# Altium schematic sheet AMS_schematic.SchDoc
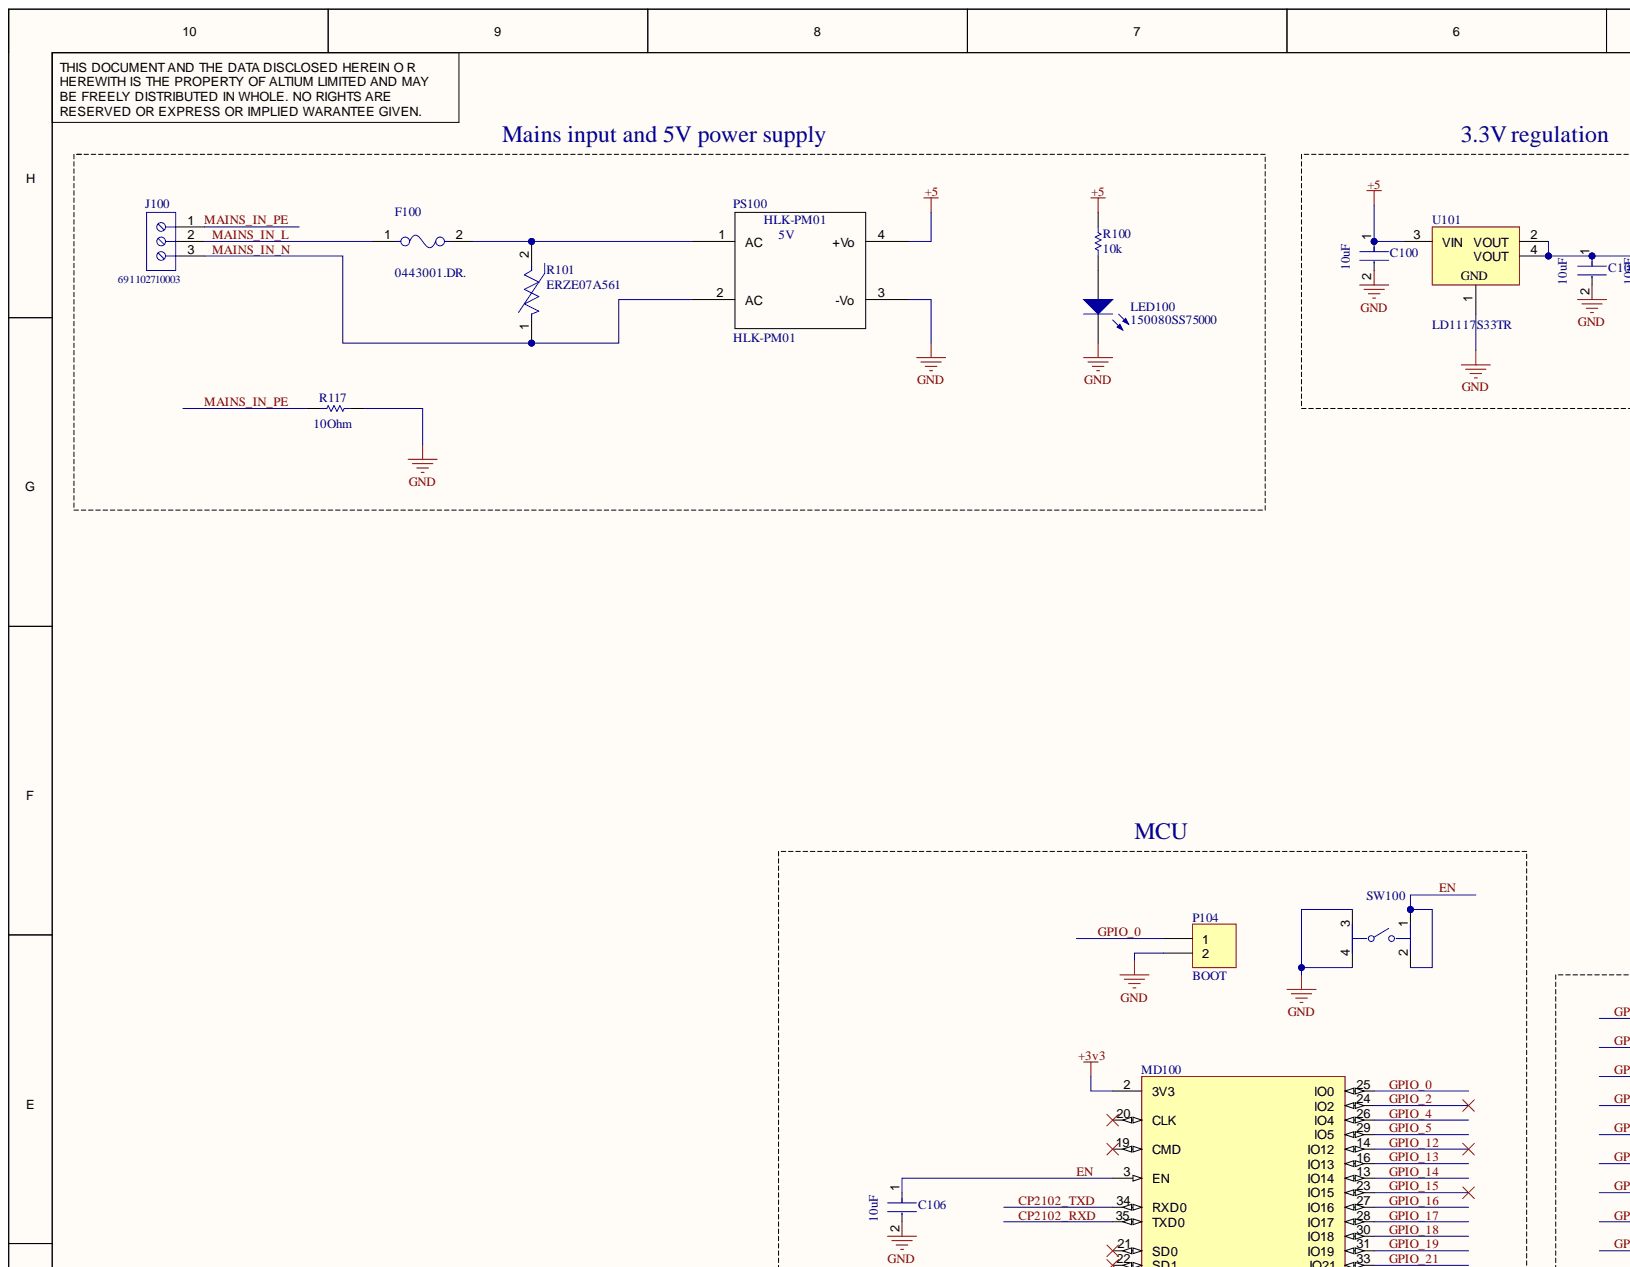
[diagram p0: AMS_schematic.SchDoc, top left]
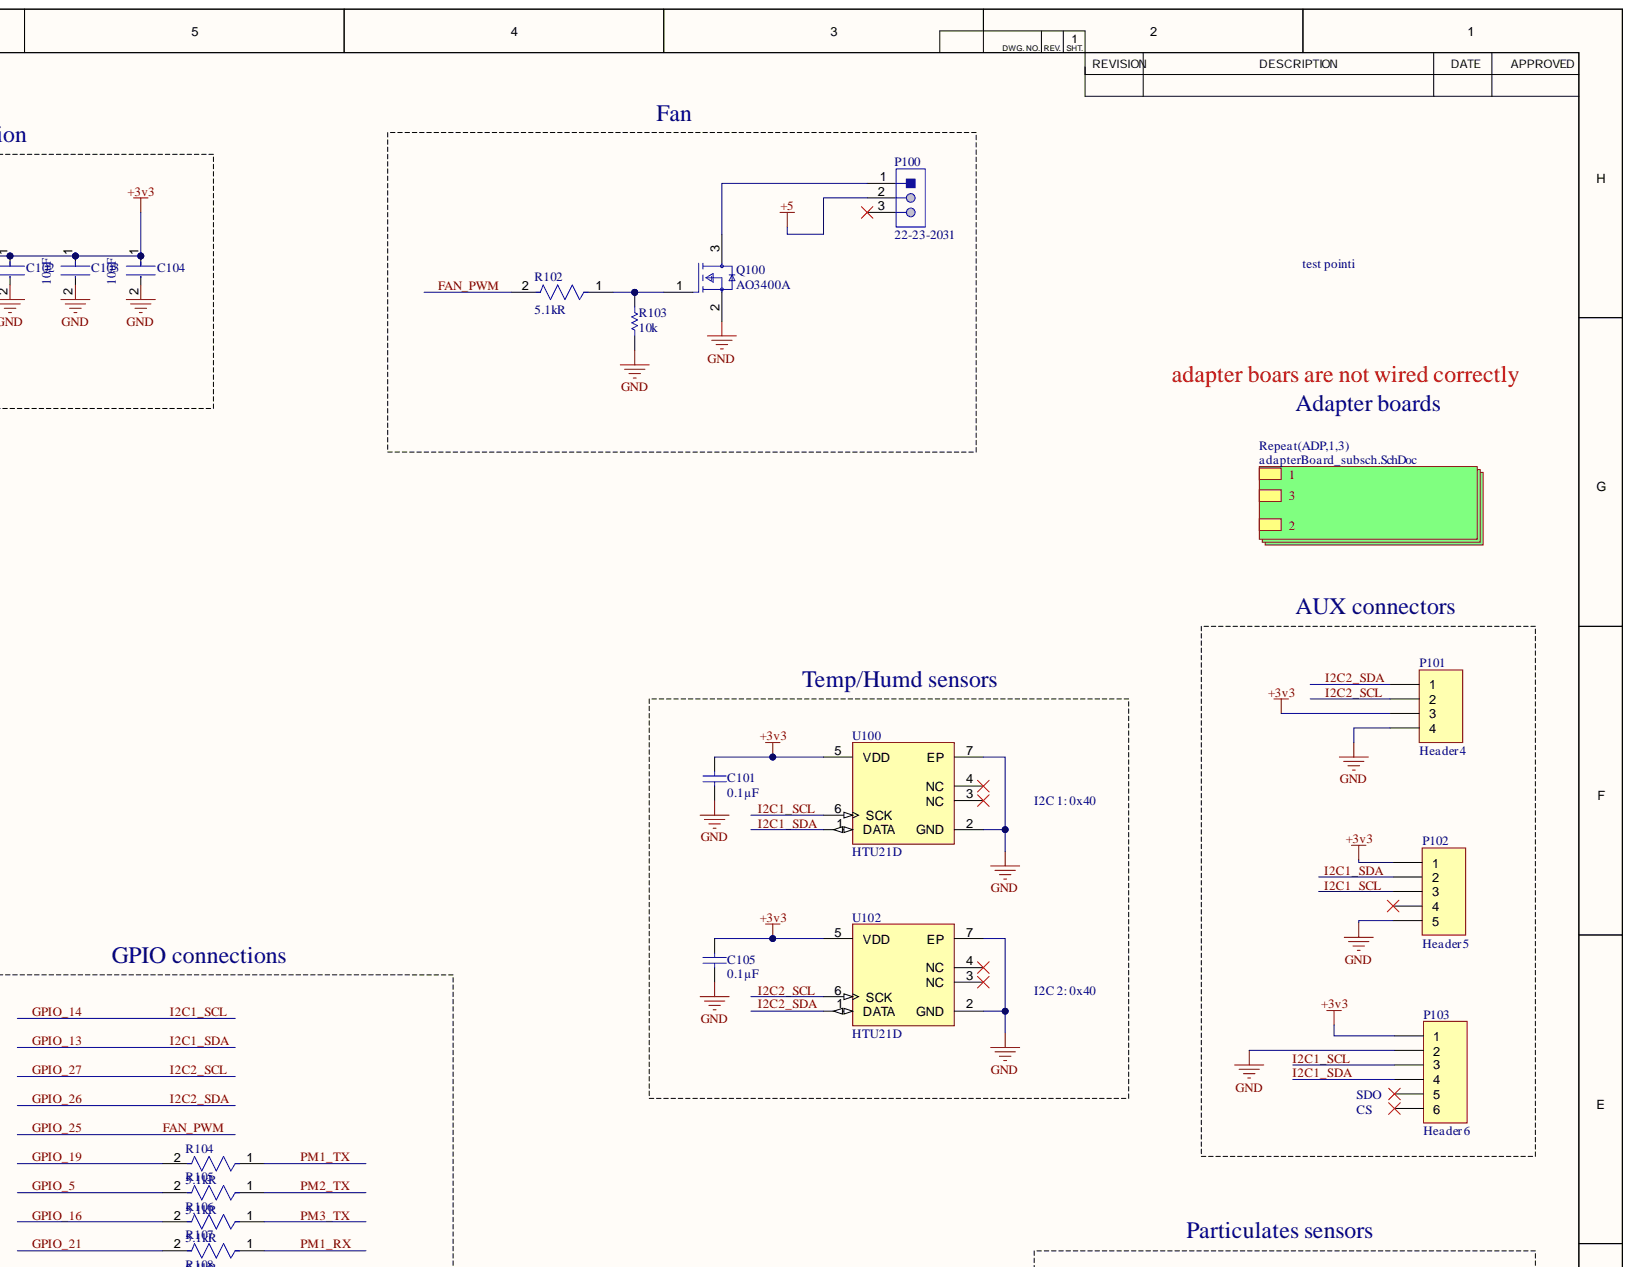
[diagram p1: AMS_schematic.SchDoc, top right]
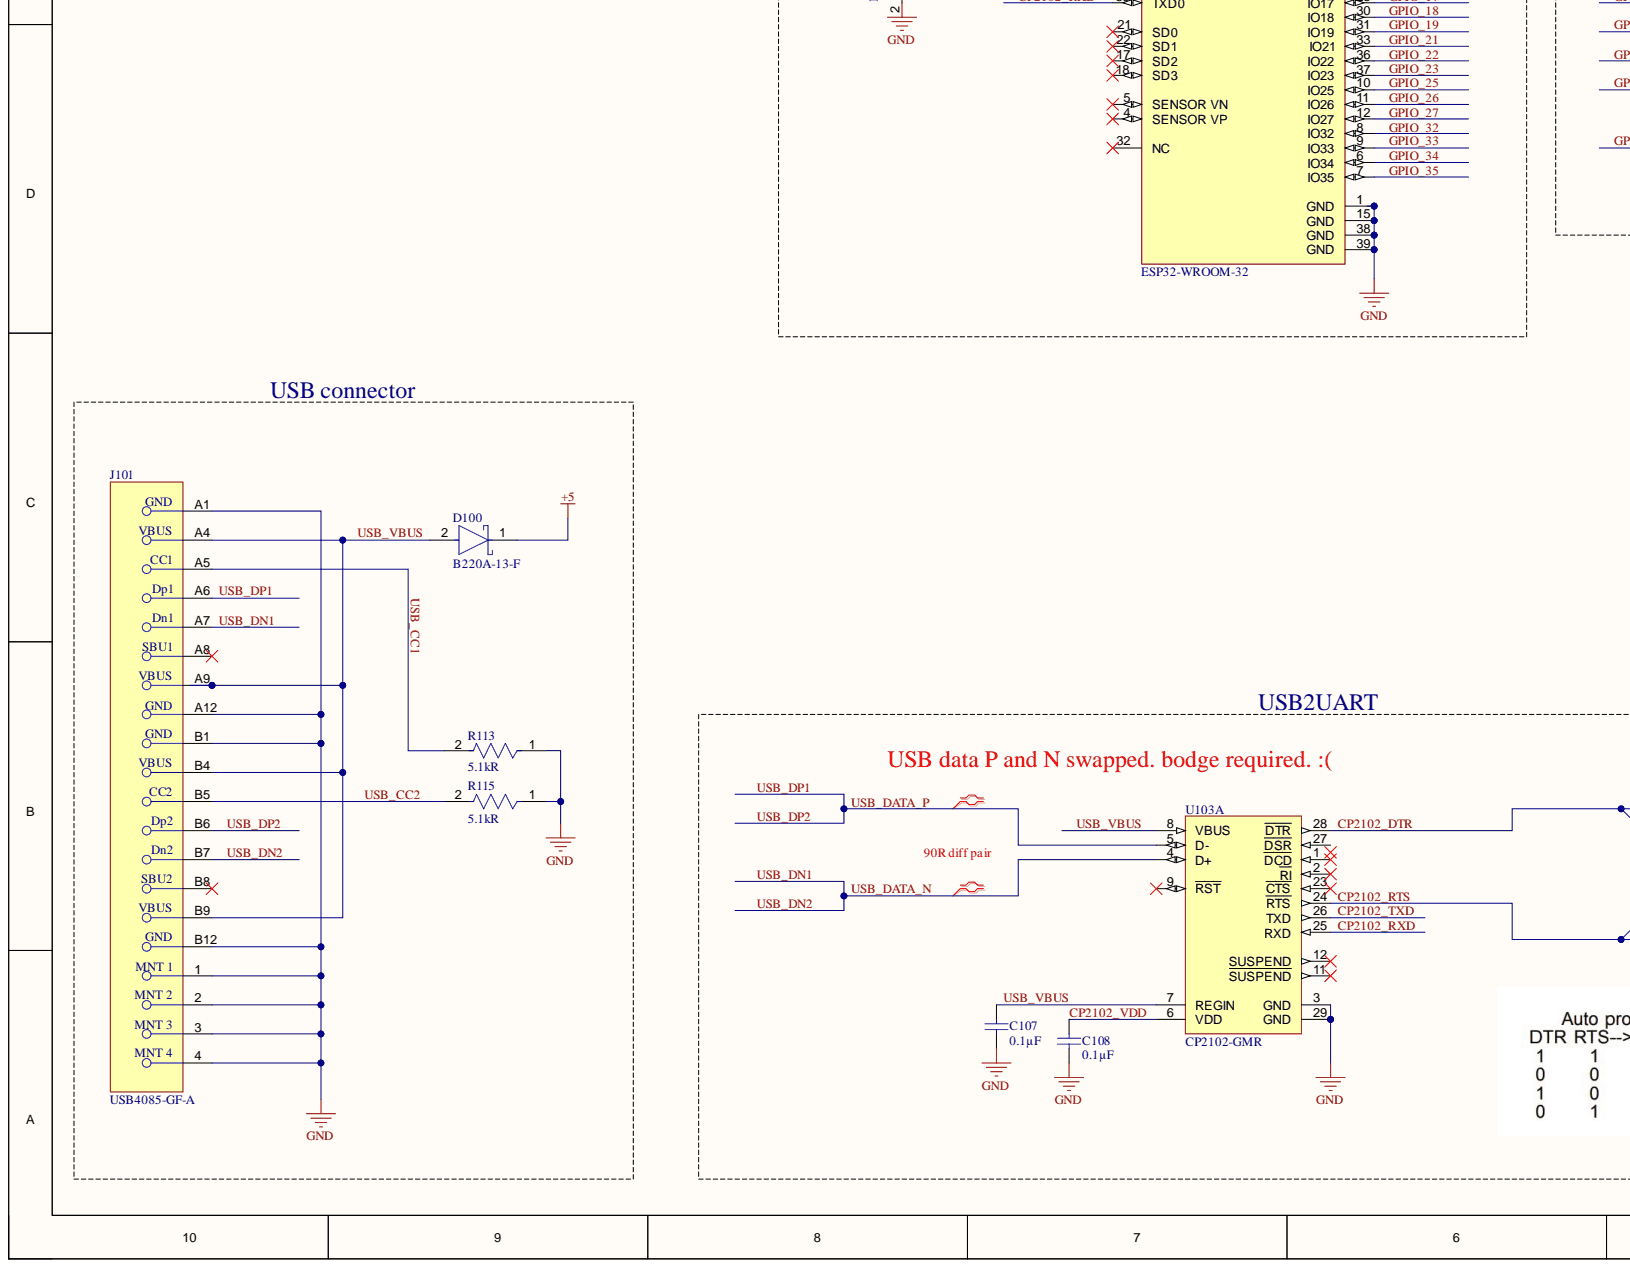
[diagram p2: AMS_schematic.SchDoc, bottom left]
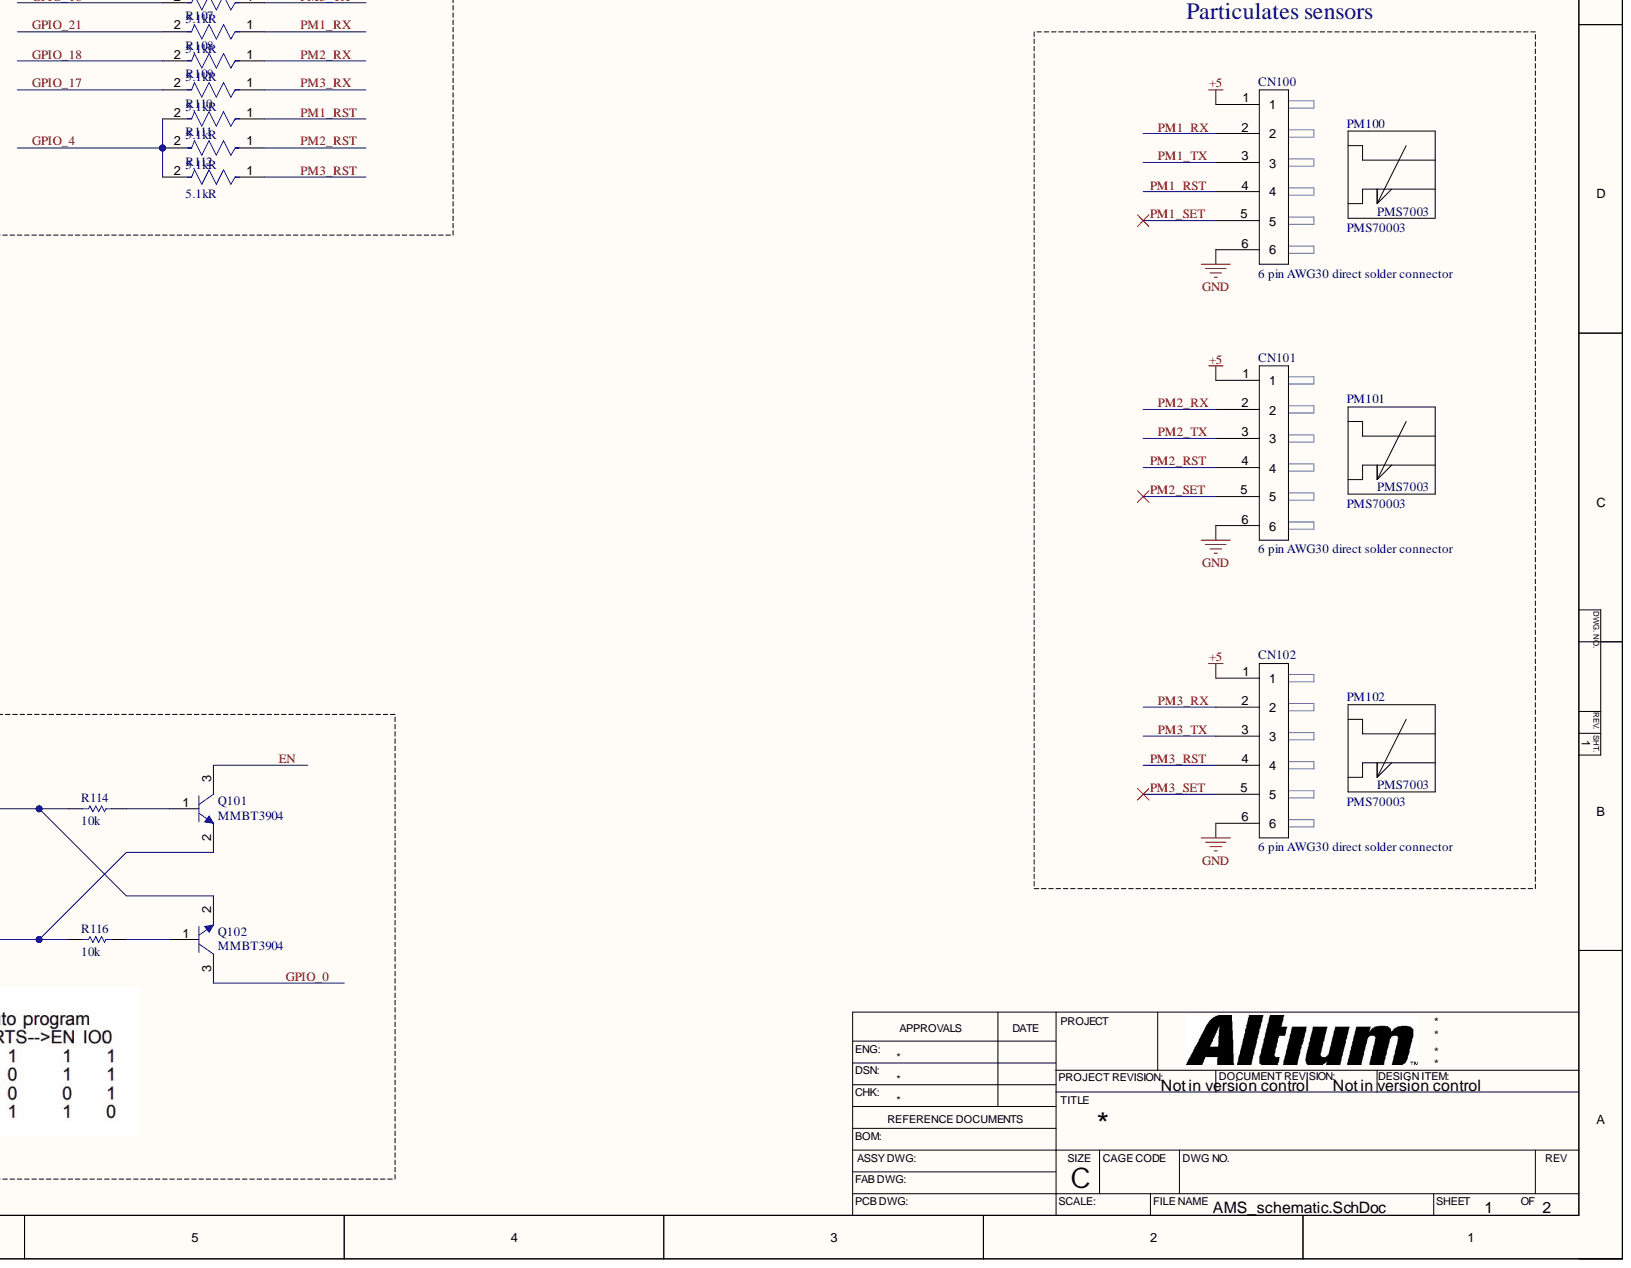
[diagram p3: AMS_schematic.SchDoc, bottom right]

## Parts
C100  Capacitance: 10uF  [FP-C3216-160-0_2-IPC_B]  (hidden comment: C3216X5R1C106K160AA)
C101  Capacitance: 0.1µF  [SAMS-C0603-2_M]  (hidden comment: CL10B104KB8NNNC)
C102  Capacitance: 10uF  [FP-C3216-160-0_2-IPC_B]  (hidden comment: C3216X5R1C106K160AA)
C103  Capacitance: 10uF  [FP-C3216-160-0_2-IPC_B]  (hidden comment: C3216X5R1C106K160AA)
C104  Capacitance: 10uF  [FP-C3216-160-0_2-IPC_B]  (hidden comment: C3216X5R1C106K160AA)
C105  Capacitance: 0.1µF  [SAMS-C0603-2_M]  (hidden comment: CL10B104KB8NNNC)
C106  Capacitance: 10uF  [FP-C3216-160-0_2-IPC_B]  (hidden comment: C3216X5R1C106K160AA)
C107  Capacitance: 0.1µF  [SAMS-C0603-2_M]  (hidden comment: CL10B104KB8NNNC)
C108  Capacitance: 0.1µF  [SAMS-C0603-2_M]  (hidden comment: CL10B104KB8NNNC)
CN100  6 pin AWG30 direct solder connector  [5 pin AWG30 direct solder connector]
CN101  6 pin AWG30 direct solder connector  [5 pin AWG30 direct solder connector]
CN102  6 pin AWG30 direct solder connector  [5 pin AWG30 direct solder connector]
D100  B220A-13-F  [FP-SMA-MFG]
F100  0443001.DR.  [FP-3403_0163_11-MFG]
J100  691102710003  [691102710003]
J101  USB4085-GF-A  [FP-USB4085-GF-A-MFG]
LED100  150080SS75000  [0805_A]
MD100  ESP32-WROOM-32  [FP-ESP32-WROOM-32-MFG]
P100  22-23-2031  [MOLX-22-23-2031_V]
P101  Header 4  [HDR1X4]
P102  Header 5  [HDR1X5]
P103  Header 6  [HDR1X6]
P104  BOOT  [HDR1X2]
PM100  PMS70003  [PMS7003 standing]
PM101  PMS70003  [PMS7003 standing]
PM102  PMS70003  [PMS7003 standing]
PS100  HLK-PM01  [HLK-PMxx]
Q100  AO3400A  [FP-SOT23-MFG]
Q101  MMBT3904  [ONSC-SOT-23-3-318-08_V]
Q102  MMBT3904  [ONSC-SOT-23-3-318-08_V]
R100  10k  [RESC2013X60X35NL10T20]
R101  ERZE07A561  [FP-ERZE07A561-MFG]
R102  Resistance: 5.1kR  [FP-CRCW0805-e3-MFG]  (hidden comment: CRCW08055K10FKEA)
R103  10k  [RESC2013X60X35NL10T20]
R104  Resistance: 5.1kR  [FP-CRCW0805-e3-MFG]  (hidden comment: CRCW08055K10FKEA)
R105  Resistance: 5.1kR  [FP-CRCW0805-e3-MFG]  (hidden comment: CRCW08055K10FKEA)
R106  Resistance: 5.1kR  [FP-CRCW0805-e3-MFG]  (hidden comment: CRCW08055K10FKEA)
R107  Resistance: 5.1kR  [FP-CRCW0805-e3-MFG]  (hidden comment: CRCW08055K10FKEA)
R108  Resistance: 5.1kR  [FP-CRCW0805-e3-MFG]  (hidden comment: CRCW08055K10FKEA)
R109  Resistance: 5.1kR  [FP-CRCW0805-e3-MFG]  (hidden comment: CRCW08055K10FKEA)
R110  Resistance: 5.1kR  [FP-CRCW0805-e3-MFG]  (hidden comment: CRCW08055K10FKEA)
R111  Resistance: 5.1kR  [FP-CRCW0805-e3-MFG]  (hidden comment: CRCW08055K10FKEA)
R112  Resistance: 5.1kR  [FP-CRCW0805-e3-MFG]  (hidden comment: CRCW08055K10FKEA)
R113  Resistance: 5.1kR  [FP-CRCW0805-e3-MFG]  (hidden comment: CRCW08055K10FKEA)
R114  10k  [RESC2013X60X35NL10T20]
R115  Resistance: 5.1kR  [FP-CRCW0805-e3-MFG]  (hidden comment: CRCW08055K10FKEA)
R116  10k  [RESC2013X60X35NL10T20]
R117  Resistance: 10Ohm  [RESC2013X60X35ML10T20]  (hidden comment: RC0805FR-0710RL)
SW100  PTS645SM43SMTR92LFS  [FP-PTS645SM43SMTR92LFS-MFG]  (value not drawn)
U100  HTU21D  [FP-HTU21D-IPC_B]
U101  LD1117S33TR  [SOT-223_L]
U102  HTU21D  [FP-HTU21D-IPC_B]
U103  CP2102-GMR  [SLAB-QFN-28-3125x3125TP_M]  (part A)

## Nets
3: C101.2 C102.1 C103.1 C104.1 C105.2 MD100.2(3V3) P101.3 P102.1 P103.1 U100.5(VDD) U101.2(VOUT) U101.4(VOUT) U102.5(VDD)
+5: C100.1 CN100.1 CN101.1 CN102.1 D100.1 P100.2 PS100.4(+Vo) R100.2 U101.3(VIN)
GND: C100.2 C101.1 C102.2 C103.2 C104.2 C105.1 C106.2 C107.1 C108.1 CN100.6 CN101.6 CN102.6 J101.1 J101.2 J101.3 J101.4 J101.A1 J101.A12 J101.B1 J101.B12 LED100.1 MD100.1(GND) MD100.15(GND) MD100.38(GND) MD100.39(GND) P101.4 P102.5 P103.2 P104.2 PS100.3(-Vo) Q100.2 R103.1 R113.1 R115.1 R117.2 SW100.3 SW100.4 U100.2(GND) U100.7(EP) U101.1 U102.2(GND) U102.7(EP) U103.3(GND) U103.29(GND)
CP2102_DTR: Q102.2 R114.1 U103.28(DTR)
CP2102_RTS: Q101.2 R116.1 U103.24(RTS)
CP2102_RXD: MD100.35(TXD0) U103.25(RXD)
CP2102_TXD: MD100.34(RXD0) U103.26(TXD)
CP2102_VDD: C108.2 U103.6(VDD)
EN: C106.1 MD100.3(EN) Q101.3 SW100.1 SW100.2
FAN_PWM: MD100.10(IO25) R102.2
GPIO_0: MD100.25(IO0) P104.1 Q102.3
GPIO_2: MD100.24(IO2)
GPIO_4: MD100.26(IO4) R110.2 R111.2 R112.2
GPIO_5: MD100.29(IO5) R105.2
GPIO_12: MD100.14(IO12)
GPIO_13: MD100.16(IO13) P102.2 P103.4 U100.1(DATA)
GPIO_14: MD100.13(IO14) P102.3 P103.3 U100.6(SCK)
GPIO_15: MD100.23(IO15)
GPIO_16: MD100.27(IO16) R106.2
GPIO_17: MD100.28(IO17) R109.2
GPIO_18: MD100.30(IO18) R108.2
GPIO_19: MD100.31(IO19) R104.2
GPIO_21: MD100.33(IO21) R107.2
GPIO_22: MD100.36(IO22)
GPIO_23: MD100.37(IO23)
GPIO_26: MD100.11(IO26) P101.1 U102.1(DATA)
GPIO_27: MD100.12(IO27) P101.2 U102.6(SCK)
GPIO_32: MD100.8(IO32)
GPIO_33: MD100.9(IO33)
GPIO_34: MD100.6(IO34)
GPIO_35: MD100.7(IO35)
MAINS_IN_L: F100.1 J100.2
MAINS_IN_N: J100.3 PS100.2(AC) R101.1
MAINS_IN_PE: J100.1 R117.1
PM1_RST: CN100.4 R110.1
PM1_RX: CN100.2 R107.1
PM1_SET: CN100.5
PM1_TX: CN100.3 R104.1
PM2_RST: CN101.4 R111.1
PM2_RX: CN101.2 R108.1
PM2_SET: CN101.5
PM2_TX: CN101.3 R105.1
PM3_RST: CN102.4 R112.1
PM3_RX: CN102.2 R109.1
PM3_SET: CN102.5
PM3_TX: CN102.3 R106.1
USB_CC1: J101.A5 R113.2
USB_CC2: J101.B5 R115.2
USB_DATA_N: J101.A7 J101.B7 U103.4(D+)
USB_DATA_P: J101.A6 J101.B6 U103.5(D-)
USB_VBUS: C107.2 D100.2 J101.A4 J101.A9 J101.B4 J101.B9 U103.7(REGIN) U103.8(VBUS)
NetF100_2: F100.2 PS100.1(AC) R101.2
NetLED100_2: LED100.2 R100.1
NetP100_1: P100.1 Q100.3
NetQ100_1: Q100.1 R102.1 R103.2
NetQ101_1: Q101.1 R114.2
NetQ102_1: Q102.1 R116.2
1:
2:

Not connected: J101 A8 B8; MD100 4(SENSOR_VP) 5(SENSOR_VN) 17(SD2) 18(SD3) 19(CMD) 20(CLK) 21(SD0) 22(SD1) 32(NC); P100 3; P102 4; P103 5 6; U100 3(NC) 4(NC); U102 3(NC) 4(NC); U103 1(DCD) 2(RI) 9(RST) 11(SUSPEND) 12(SUSPEND) 23(CTS) 27(DSR)

## Library data of the parts
C100 C102-C104 C106 = CMP-08246-003443-1: Multilayer Ceramic Capacitors 10uF ±10% 16V X5R SMD 1206; Case Code (Imperial): 1206; Case Code (Metric): 3216; Case/Package: 1206; Component Kind: Standard; Construction: Flat; Depth: 1.6mm; Dielectric: X5R; Dielectric Material: Ceramic; Height: 1.6mm; Lead Free: Lead Free; Length: 3.2mm; Library Name: Altium Content Vault; Max Operating Temperature: 85°C; Min Operating Temperature: -55°C; Mount: Surface Mount; Package Quantity: 1; Packaging: Tape and Reel; Radiation Hardening: No; REACH SVHC: No SVHC; Resistor Type: Ceramic; RoHS Compliant: Yes; Series: C; Termination: SMD/SMT; Thickness: 0.071inch; Tolerance: 10%; Voltage: 16V; Voltage Rating: 16V; Voltage Rating (DC): 16V; Weight: 0.000571oz; Width: 0.063inch
C101 C105 C107 C108 = CMP-2000-05332-1: Multi-layer Ceramic Capacitor, -55 to 125 degC, 2-Pin SMD (0603), RoHS, Tape and Reel; Manufacturer: Samsung Electro-Mechanics; Dielectric: X7R; Rated Voltage: 50V; Tolerance (%): ±10%; Case Size/Dimension: 0603; Component Kind: Standard; Datasheet URL: https://datasheet.ciiva.com/29198/456651-da-01-en-vs-kondensator-0605-29198839.pdf; Datasheet Version: Nov-10; Library Name: Altium Content Vault; Manufacturer URL: http://www.samsungsem.com/global/index.jsp
D100 = CMP-12790-000072-1: DIODE SCHOTTKY 20V 2A SMA; Capacitance: 200pF; Element Configuration: Single; Forward Voltage: 500mV; Max Forward Surge Current (Ifsm): 50A; Number of Elements: 1; Peak Reverse Current: 500uA; Polarity: Standard; Average Rectified Current: 2A; Case/Package: SMA; Component Kind: Standard; Contact Plating: Tin; Current Rating: 2A; Forward Current: 2A; Height: 2.1mm; Lead Free: Lead Free; Length: 4.6mm; Library Name: Altium Content Vault; Max Operating Temperature: 150°C; Max Repetitive Reverse Voltage (Vrrm): 20V; Max Reverse Voltage (DC): 20V; Min Operating Temperature: -65°C; Mount: Surface Mount; Number of Pins: 2; Number of Terminals: 2; Output Current: 2A; Package Quantity: 5000; Packaging: Tape and Reel; Peak Non-Repetitive Surge Current: 50A; Radiation Hardening: No; REACH SVHC: No SVHC; Termination: SMD/SMT; Voltage Rating (DC): 20V; Weight: 0.002258oz; Width: 2.92mm
F100 = CMP-26293-000009-1: FUSE, SMD, 1A, SLOW BLOW; Manufacturer: Schurter Inc; Manufacturer Part Number: 3403.0163.11; Fuse I2T: 0.54A2Sec; Fuse Voltage Drop: 600mV; Max Fuse Ac Voltage: 250V; Max Fuse Dc Voltage: 125V; Ambient Temperature Range High: +125°C; Ambient Temperature Range Low: -55°C; Automotive: No; Category: Fuse; Component Kind: Standard; ComponentLink2Description: Datasheet; ComponentLink2URL: https://www.farnell.com/datasheets/717394.pdf; Device Class L1: Circuit Protection; Device Class L2: Fuses; Device Class L3: unset; Digikey Description: FUSE BRD MNT 500MA 250VAC 125VDC; Farnell #: 1664602; Fuse Blow Speed: Slow; Fuse Current: 1A; Height: 3mm; Lead Free: Yes; Library Name: Altium Content Vault; Mouser Description: Surface Mount Fuses UMT 250 500mA 277V Slow; Octopart: https://octopart.com/3403.0163.11-schurter-65395; Package: 0; RoHS: TRUE; Voltage Rating: 125V
J100 = CMP-1502-03259-1: Series 102 - 5.00 mm Horizontal Entry Modular with Pressure Clamp WR-TBL, 3 pin; Manufacturer: Wurth Elektronik; Pin Number: 3; Pitch: 5.00mm; Component Kind: Standard; Datasheet URL: http://katalog.we-online.de/em/datasheet/6911027100xx.pdf; Farnell #: 1641935; Library Name: Altium Content Vault; Manufacturer URL: http://www.we-online.de; Mounting Technology: THT; Operating Temperature: -40to105degC; Package Reference: 691102710003; Packaging: Box; Part Number: 691102710003; Publisher: WurthElektronik
J101 = CMP-196119-000001-1: CONN RCPT USB2.0 TYPEC 16POS; Manufacturer: Global Connector Technology; Conn Orientation: Right Angle; Current Rating: 4.25A; Is Female: yes; Number Of Contacts: 16; Pitch: 0.85mm; Shielding: true; Voltage Rating: 20V; Automotive: No; Category: Conn; Component Kind: Standard; ComponentLink2Description: Datasheet; ComponentLink2URL: https://gct.co/files/drawings/usb4085.pdf; Contact Material: Gold,Nickel; Device Class L1: Connectors; Device Class L2: USB Connectors; Device Class L3: unset; Digikey Description: CONN RCPT USB2.0 TYPEC 16POS; Farnell #: 2924867; Height: 3.66mm; Lead Free: Yes; Library Name: Altium Content Vault; Manufacturer Part Number: USB4085-GF-A; Octopart: https://octopart.com/usb4085-gf-a-global+connector+technology-94773699; Package: 0; RoHS: TRUE; Temperature: -40°C to +85°C; Temperature Range High: +85°C; Temperature Range Low: -40°C; Usb Standard: USB 2.0
LED100 = CMP-1426-00010-1: SMD mono-color Chip LED, WL-SMCW, Super Red; Manufacturer: Wuerth Elektronik; Color: Super Red; Component Kind: Standard; ComponentLink1Description: Manufacturer URL; ComponentLink1URL: http://www.we-online.com; ComponentLink2Description: Datasheet; ComponentLink2URL: http://katalog.we-online.com/led/datasheet/150080SS75000.pdf; Library Name: Altium Content Vault; PackageReference: 0805_A; PartNumber: 150080SS75000
MD100 = CMP-194065-000008-1: RX TXRX MOD WIFI SURFACE MOUNT; Data Rate: 150Mbps; Frequency: 2.5GHz; Operating Supply Voltage: 3.6V; Sensitivity: -98dBm; Case/Package: SMD/SMT; Component Kind: Standard; Library Name: Altium Content Vault; Max Operating Temperature: 85°C; Min Operating Temperature: -40°C; Mount: Surface Mount; Package Quantity: 550; Packaging: Cut Tape; Weight: 0.088185oz
P100 = CMP-2000-05242-1: Male Header, Pitch 2.54 mm, 1 x 3 Position, Height 8.18 mm, Tail Length 3.56 mm, RoHS, Bulk; Brand: Molex; Current Rating: 4A; Mounting Angle: Vertical; Pitch: 2.54mm; Voltage Rating: 250V; Component Kind: Standard; Contact Gender: Pin(Male); Contact Material: Brass; Contact Plating: Tin; Datasheet URL: https://datasheet.ciiva.com/19472/232274-19472863.pdf; Datasheet Version: Sep-01; Factory Pack Quantity: 16000; Housing Material: Nylon; Library Name: Altium Content Vault; Manufacturer: Molex; Manufacturer URL: http://www.molex.com/; Mounting Style: ThroughHole; Number of Positions: 3Position; Number of Rows: 1Row; Packaging: Bulk; Product: Headers; Product Category: Headers&WireHousings; RoHS: Details; Series: 6373; Termination Style: ThroughHole; Tradename: KK; Type: Locking
P101 = Header 4: Header, 4-Pin; Component Kind: Standard; LatestRevisionDate: 17-Jul-2002; LatestRevisionNote: Re-released for DXP Platform.; Library Name: Miscellaneous Connectors.IntLib; Signal Integrity: Connector; Publisher: Altium Limited
P102 = Header 5: Header, 5-Pin; Component Kind: Standard; LatestRevisionDate: 17-Jul-2002; LatestRevisionNote: Re-released for DXP Platform.; Library Name: Miscellaneous Connectors.IntLib; Signal Integrity: Connector; Publisher: Altium Limited
P103 = Header 6: Header, 6-Pin; Component Kind: Standard; LatestRevisionDate: 17-Jul-2002; LatestRevisionNote: Re-released for DXP Platform.; Library Name: Miscellaneous Connectors.IntLib; Signal Integrity: Connector; Publisher: Altium Limited
P104 = Header 2: Header, 2-Pin; Component Kind: Standard; LatestRevisionDate: 17-Jul-2002; LatestRevisionNote: Re-released for DXP Platform.; Library Name: Miscellaneous Connectors.IntLib; Signal Integrity: Connector; Publisher: Altium Limited
PM100-PM102 = PMS70003: PM1/2.5/10 airborne particle sensor. Silk tape mounting pattern only. Needs sepparate data connector.; Component Kind: Standard; Library Name: planinsekLib.IntLib
PS100 = HLK-PM01: HLK-PM01 compact AC-DC power supply 5V 600mA; Manufacturer: Hi-Link; Component Kind: Standard; ComponentLink1Description: Manufacturer page; ComponentLink1URL: http://www.hlktech.net/product_detail.php?ProId=54; Output: 5V 600mA; Library Name: planinsekLib.IntLib
Q100 = CMP-83955-000006-1: MOSFET N-CH 30V 5.7A SOT23; Gate to Source Voltage (Vgs): 12V; Input Capacitance: 630pF; Max Power Dissipation: 1.4W; Number of Elements: 1; Rds On Max: 26.5mR; Case/Package: SOT-23; Component Kind: Standard; Continuous Drain Current (ID): 5.7A; Drain to Source Voltage (Vdss): 30V; Lead Free: Lead Free; Library Name: Altium Content Vault; Max Operating Temperature: 150°C; Min Operating Temperature: -55°C; Mount: Surface Mount; Number of Pins: 3; Packaging: Tape and Reel; Power Dissipation: 1.4W; Radiation Hardening: No
Q101 Q102 = CMP-1048-00631-1: General Purpose Transistor, NPN Silicon, 3-Pin SOT-23, Pb-Free, Tape and Reel; Manufacturer: On Semiconductor; PartNumber: MMBT3904LT1G; fT Min (MHz): 300; IC Continuous (A): 0.2; Component Kind: Standard; ComponentLink1Description: Manufacturer URL; ComponentLink1URL: http://www.onsemi.com/; ComponentLink2Description: Datasheet; ComponentLink2URL: http://www.onsemi.cn/pub_link/Collateral/MMBT3904LT1-D.PDF; ComponentLink3Description: Package Specification; ComponentLink3URL: http://www.onsemi.cn/pub_link/Collateral/318-08.PDF; DatasheetVersion: Rev. 12; Library Name: Altium Content Vault; Mounting Technology: Surface Mount; PackageDescription: 3-Pin Small Outline Transistor, Body 2.92 x 1.3 mm, Pitch 0.95 mm; PackageReference: SOT-23-3-318-08; PackageVersion: Rev. AP, 11/2009; Packing: Tape and Reel; Polarity: NPN; RoHS: TRUE
R100 R103 R114 R116 = CMP-1659-00013-4: Chip Resistor, 10 KOhm, +/- 1%, 0.125 W, -55 to 155 degC, 0805 (2012 Metric), RoHS, Tape and Reel; Manufacturer: Yageo; Voltage Rating: 150 V; Component Kind: Standard; ComponentLink1Description: Manufacturer URL; ComponentLink1URL: http://www.yageo.com/; ComponentLink2Description: Datasheet; ComponentLink2URL: http://www.yageo.com/documents/recent/PYu-RC_Group_51_RoHS_L_04.pdf; DatasheetVersion: Rev. 4, 02/2016; Height: 0.5 mm; Length: 2 mm; Library Name: Altium Content Vault; Max Operating Temperature: 155 degC; Min Operating Temperature: -55 degC; Mount: Surface Mount; Mounting Technology: Surface Mount; Package Quantity: 5000; PackageDescription: 2-Pin Surface Mounted Device, Body 2 x 1.25 mm; PackageReference: 0805; Packaging: Tape and Reel; Pins: 2; REACH SVHC: No SVHC; RoHSCompliant: true
R101 = CMP-05593-000004-1: VARISTOR 560V 2.5KA DISC 9MM; Manufacturer: Panasonic Electronic Components; Capacitance: 140pF; Clamping Current: 25A; Clamping Voltage: 930V; Value: 140pF; Voltage Rating Ac: 350V; Voltage Rating Dc: 450V; Automotive: No; Category: Res; Component Kind: Standard; ComponentLink2Description: Datasheet; ComponentLink2URL: https://industrial.panasonic.com/cdbs/www-data/pdf/AWA0000/AWA0000C18.pdf; Device Class L1: Circuit Protection; Device Class L2: Varistors; Device Class L3: unset; Digikey Description: VARISTOR 560V 2.5KA DISC 9MM; Height: 13.5mm; Lead Free: Yes; Library Name: Altium Content Vault; Manufacturer Part Number: ERZE07A561; Mouser Description: Varistor [Panasonic Automotive & Industrial Systems] ERZE07A561 Varistor; Octopart: https://octopart.com/erz-e07a561-panasonic-29718164; Package: ERZE07A561; RoHS: TRUE; Temperature: -40°C to +85°C; Temperature Range High: +85°C; Temperature Range Low: -40°C; Varistor Voltage: 560V
R102 R104-R113 R115 = CMP-2001-04024-2: RES Thick Film, 5.1kΩ, 1%, 0.125W, 100ppm/°C, 0805; Max Power Dissipation: 125mW; Voltage Rating: 150V; Case Code (Imperial): 0805; Case Code (Metric): 2012; Case/Package: 0805; Component Kind: Standard; Composition: Thick Film; Contact Plating: Tin; Depth: 1.25mm; Features: Automotive AEC-Q200; Height: 0.45mm; Lead Free: Lead Free; Length: 2mm; Library Name: Altium Content Vault; Max Operating Temperature: 155°C; Military Standard: Not; Min Operating Temperature: -55°C; Mount: Surface Mount; Number of Terminations: 2; Package Quantity: 10; Packaging: Tape and Reel; Power Rating: 125mW; Radiation Hardening: No; Temperature Coefficient: 100ppm/°C; Termination: SMD/SMT; Tolerance: 1%; Voltage Rating (DC): 150V; Weight: 0.000194oz; Width: 0.049inch
R117 = CMP-2100-03670-1: Chip Resistor, 10 Ohm, +/- 1%, 0.125 W, -55 to 155 degC, 0805 (2012 Metric), RoHS, Tape and Reel; Manufacturer: Yageo; Max Power Dissipation: 125mW; Temperature Coefficient: 200ppm/degreesC; Voltage Rating: 150V; Case Code (Imperial): 0805; Case Code (Metric): 2012; Case/Package: 0805; Component Kind: Standard; Composition: ThickFilm; Datasheet URL: http://www.yageo.com/NewPortal/yageodocoutput?fileName=/pdf/R-Chip/PYu-RC_Group_51_RoHS_L_5.pdf; Datasheet Version: Rev. 5, 10/2016; Depth: 1.25mm; Height: 0.5mm; Lead Free: LeadFree; Length: 2mm; Library Name: Altium Content Vault; Manufacturer URL: http://www.yageo.com/; Max Operating Temperature: 155degC; Min Operating Temperature: -55degC; Number of Pins: 2; Number of Terminations: 2; Package Description: 2-Pin Surface Mounted Device, Body 2 x 1.25 mm; Package Quantity: 1; Package Reference: 0805; Packaging: TapeandReel; Power Rating: 125mW; REACH SVHC: NoSVHC; RoHSCompliant: true; Termination: SMD/SMT; Tolerance: 1%; Voltage Rating (DC): 150V; Width: 1.25mm
SW100 = CMP-2000-05160-2: SWITCH TACTILE SPST-NO 0.05A 12V; Actuator Length: 0.85mm; Actuator Material: Nylon; Actuator Type: Standard; Contact Resistance: 100mR; Current Rating: 50mA; Illumination Type: Non-Illuminated; Mechanical Life: 100000Cycles; Operating Force: 160gf; Switch Function: Off-Mom; Throw Configuration: SPST; Case/Package: SMD/SMT; Color: Black; Component Kind: Standard; Contact Material: Silver; Contact Plating: Silver; Depth: 9mm; Lead Free: Lead Free; Length: 6mm; Library Name: Altium Content Vault; Max Current Rating: 50mA; Max Operating Temperature: 60°C; Max Voltage Rating (DC): 12V; Min Operating Temperature: -20°C; Mount: Surface Mount; Orientation: Straight; Package Quantity: 1; Packaging: Tape and Reel; REACH SVHC: No SVHC; Termination: Gull Wing; Voltage Rating (DC): 12V
U100 U102 = CMP-03340-000003-1: Digital Relative Humidity sensor with Temperature output; Manufacturer: TE; Accuracy: 2%; Interface: I2C,2-Wire; Max Supply Voltage: 3.6V; Min Supply Voltage: 1.5V; Operating Supply Current: 450uA; Sensor Output: I2C; Ambient Temperature Range High: +125°C; Ambient Temperature Range Low: -40°C; Automotive: No; Category: IC; Component Kind: Standard; ComponentLink2Description: Datasheet; ComponentLink2URL: https://www.te.com/commerce/DocumentDelivery/DDEController?Action=showdoc&DocId=Data+Sheet%7FHPC199_6%7FA6%7Fpdf%7FEnglish%7FENG_DS_HPC199_6_A6.pdf%7FCAT-HSC0004; Device Class L1: Sensors; Device Class L2: Temperature and Humidity Sensors; Device Class L3: unset; Height: 1mm; Ipc Land Pattern Name: DFN300X300X90-6; Lead Free: Yes; Library Name: Altium Content Vault; Manufacturer Part Number: HTU21D; Octopart: https://octopart.com/htu21d-te+connectivity-61614170; Package: 0; RoHS: TRUE; Temperature: -40°C to +125°C; Temperature Range High: +125°C; Temperature Range Low: -40°C
U101 = CMP-0244-00310-1: Fixed Low Drop Positive Voltage Regulator, 3.3V, 4-Pin SOT-223, Tape and Reel; Component Kind: Standard; ComponentLink1Description: Manufacturer URL; ComponentLink1URL: http://www.st.com; ComponentLink2Description: Datasheet; ComponentLink2URL: http://www.st.com/internet/com/TECHNICAL_RESOURCES/TECHNICAL_LITERATURE/DATASHEET/CD00000544.pdf; DatasheetVersion: 1/11/2011; Library Name: Altium Content Vault; PackageDescription: 4-Pin SOT-223 Package, 6.5 x 3.5 mm Body, 2.3 mm Pitch, 7 mm Lead Span; PackageReference: SOT-223; PackageVersion: 2010
U103 = CMP-2000-04924-1: Single-Chip USB to URAT Bridge, 3.3 V, -40 to 85 degC, 28-Pin QFN, RoHS, Tape and Reel; Manufacturer: Silicon Labs; Data Rate: 1000kbps; Interface Circuit Standard 1: RS-232; Number of Channels: 1; Operating Supply Voltage (Max): 3.6V; Operating Supply Voltage (Min): 3V; Operating Supply Voltage (Typ): 3.3V; Rad Hardened: No; Supply Current: 26mA; Component Kind: Standard; Datasheet URL: http://www.mouser.com/ds/2/368/CP2102-9-247052.pdf; Datasheet Version: Rev. 1.6, 12/2013; Library Name: Altium Content Vault; Manufacturer URL: http://www.silabs.com/; Mounting: SurfaceMount; Operating Temperature (Max): 85C; Operating Temperature (Min): -40C; Operating Temperature Classification: Industrial; Package Description: 28-Pin Quad-Flat No-Leads Package, Body 5 x 5 mm, Pitch 0.5 mm; Package Reference: QFN-28; Package Type: QFNEP; Package URL: http://www.silabs.com/Support%20Documents/TechnicalDocs/C8051T61x.pdf; Packaging: TapeandReel; Pin Count: 28; Transmitter and Receiver FIFO Counter: No
CN100-CN102 = 6 pin AWG30 direct solder connector: Component Kind: Standard; Library Name: planinsekLib.IntLib
